# Altium schematic sheet 3A04xx_Oxocard_Mini_Science_MCU.SchDoc
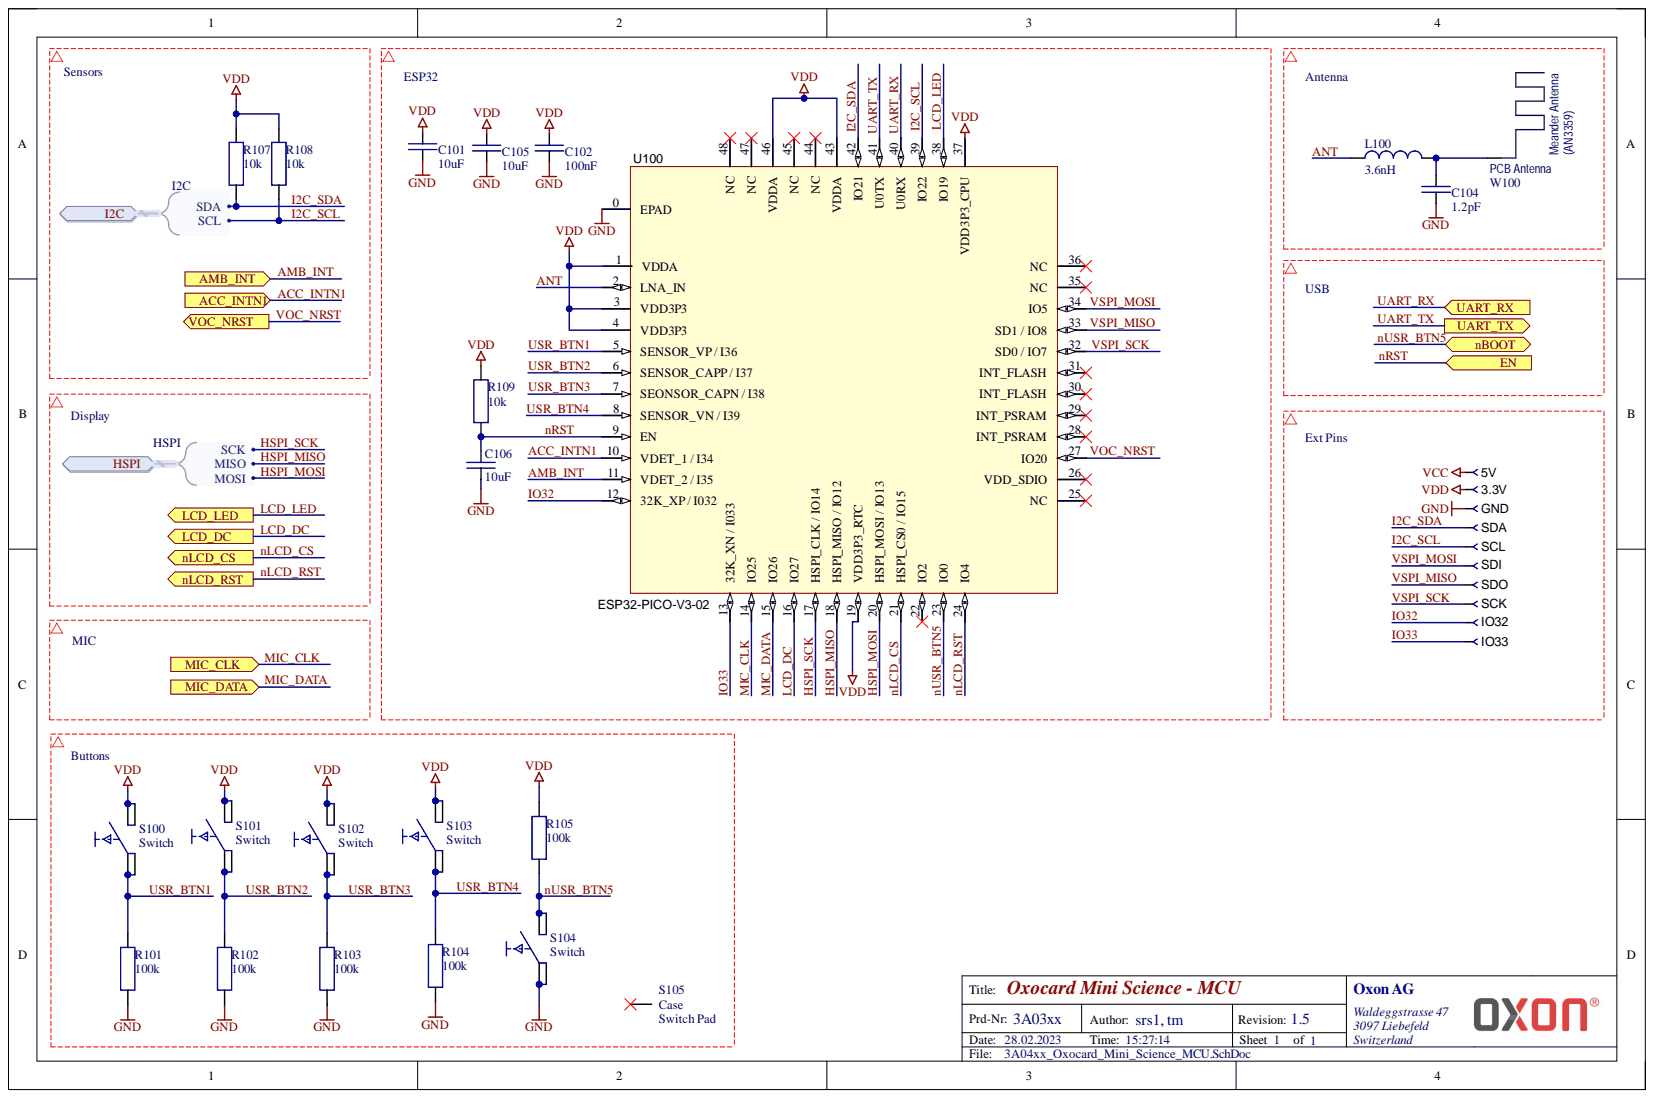
[diagram p0: 3A04xx_Oxocard_Mini_Science_MCU.SchDoc]

## Parts
3.3V  TP-2x3mm  [TP_2X3MM]  (value not drawn)
5V  TP-2x3mm  [TP_2X3MM]  (value not drawn)
C101  10uF  [CAPC1005X60]
C102  100nF  [CAPC1005X60]
C104  1.2pF  [CAPC1005X60]
C105  10uF  [CAPC1005X60]
C106  10uF  [CAPC1005X60]
GND  TP-2x3mm  [TP_2X3MM]  (value not drawn)
IO32  TP-2x3mm  [TP_2X3MM]  (value not drawn)
IO33  TP-2x3mm  [TP_2X3MM]  (value not drawn)
L100  3.6nH  [INDC1005X60]
R101  100k  [RESC1005X40]
R102  100k  [RESC1005X40]
R103  100k  [RESC1005X40]
R104  100k  [RESC1005X40]
R105  100k  [RESC1005X40]
R107  10k  [RESC1005X40]
R108  10k  [RESC1005X40]
R109  10k  [RESC1005X40]
S100  Switch  [Switch_Frog_7.6x7.6]
S101  Switch  [Switch_Frog_7.6x7.6]
S102  Switch  [Switch_Frog_7.6x7.6]
S103  Switch  [Switch_Frog_7.6x7.6]
S104  Switch  [Switch_Frog_7.6x7.6]
S105  Switch Pad  [Switch_PAD]
SCK  TP-2x3mm  [TP_2X3MM]  (value not drawn)
SCL  TP-2x3mm  [TP_2X3MM]  (value not drawn)
SDA  TP-2x3mm  [TP_2X3MM]  (value not drawn)
SDI  TP-2x3mm  [TP_2X3MM]  (value not drawn)
SDO  TP-2x3mm  [TP_2X3MM]  (value not drawn)
U100  ESP32-PICO-V3-02  [QFN48-7x7mm]
W100  BLE-Antenna  [W-BLE-PCB-ANT]  (value not drawn)

## Ports
ACC_INTN1, AMB_INT, EN, HSPI, HSPI.MISO, HSPI.MOSI, HSPI.SCK, I2C, I2C.SCL, I2C.SDA, LCD_DC, LCD_LED, MIC_CLK, MIC_DATA, nBOOT, nLCD_CS, nLCD_RST, UART_RX, UART_TX, VOC_NRST

## Nets
GND: C101.1 C102.1 C104.1 C105.1 C106.1 GND.1 R101.1 R102.1 R103.1 R104.1 S104.1 S104.2 U100.0(EPAD)
VCC: 5V.1
VDD: 3.3V.1 C101.2 C102.2 C105.2 R105.2 R107.2 R108.2 R109.2 S100.3 S100.4 S101.3 S101.4 S102.3 S102.4 S103.3 S103.4 U100.1(VDDA) U100.3(VDD3P3) U100.4(VDD3P3) U100.19(VDD3P3_RTC) U100.37(VDD3P3_CPU) U100.43(VDDA) U100.46(VDDA)
ACC_INTN1: U100.10(VDET_1_/_I34) ; port ACC_INTN1
AMB_INT: U100.11(VDET_2_/_I35) ; port AMB_INT
ANT: L100.1 U100.2(LNA_IN)
HSPI_MISO: U100.18(HSPI_MISO_/_IO12) ; port HSPI
HSPI_MOSI: U100.20(HSPI_MOSI_/_IO13) ; port HSPI
HSPI_SCK: U100.17(HSPI_CLK_/_IO14) ; port HSPI
I2C_SCL: R108.1 SCL.1 U100.39(IO22) ; port I2C
I2C_SDA: R107.1 SDA.1 U100.42(IO21) ; port I2C
IO32: IO32.1 U100.12(32K_XP_/_I032)
IO33: IO33.1 U100.13(32K_XN_/_I033)
LCD_DC: U100.16(IO27) ; port LCD_DC
LCD_LED: U100.38(IO19) ; port LCD_LED
MIC_CLK: U100.14(IO25) ; port MIC_CLK
MIC_DATA: U100.15(IO26) ; port MIC_DATA
nLCD_CS: U100.21(HSPI_CS0_/_IO15) ; port nLCD_CS
nLCD_RST: U100.24(IO4) ; port nLCD_RST
nRST: C106.2 R109.1 U100.9(EN) ; port EN
nUSR_BTN5: R105.1 S104.3 S104.4 U100.23(IO0) ; port nBOOT
UART_RX: U100.40(U0RX) ; port UART_RX
UART_TX: U100.41(U0TX) ; port UART_TX
USR_BTN1: R101.2 S100.1 S100.2 U100.5(SENSOR_VP_/_I36)
USR_BTN2: R102.2 S101.1 S101.2 U100.6(SENSOR_CAPP_/_I37)
USR_BTN3: R103.2 S102.1 S102.2 U100.7(SEONSOR_CAPN_/_I38)
USR_BTN4: R104.2 S103.1 S103.2 U100.8(SENSOR_VN_/_I39)
VOC_NRST: U100.27(IO20) ; port VOC_NRST
VSPI_MISO: SDO.1 U100.33(SD1_/_IO8)
VSPI_MOSI: SDI.1 U100.34(IO5)
VSPI_SCK: SCK.1 U100.32(SD0_/_IO7)
NetC104_2: C104.2 L100.2 W100.1

Not connected: S105 0; U100 22(IO2) 25(NC) 26(VDD_SDIO) 28(INT_PSRAM) 29(INT_PSRAM) 30(INT_FLASH) 31(INT_FLASH) 35(NC) 36(NC) 44(NC) 45(NC) 47(NC) 48(NC)

## Library data of the parts
3.3V 5V GND IO32 IO33 SCK SCL SDA SDI SDO = TP-20x30-SMT: Test Point, 2x3mm; Component Kind: Standard; Library Name: XLib.SCHLIB
C101 C105 C106 = C-CAP0402_10u: SMD capacitor 6.3V 20%; Manufacturer: Wurth Electronics; Manufacturer Designator: ZRB15XR60J106ME2D; Component Kind: Standard; Distributor: Mouser; Distributor Part-Number: 81-ZRB15XR60J106ME2D; Library Name: XLib.SCHLIB
C102 = C-CAP0402_100n: SMD capacitor 10V 5%; Manufacturer: Wurth Electronics; Manufacturer Designator: GRM155R61A104JA1D; Component Kind: Standard; Distributor: Mouser; Distributor Part-Number: 81-GRM155R61A104JA1D; Library Name: XLib.SCHLIB
C104 = C-CAP0402_1p2: SMD capacitor 50V +/-0.05pF; Manufacturer: Murata Electronics; Manufacturer Designator: GJM1555C1H1R2WB01J; Component Kind: Standard; Distributor: Mouser; Distributor Part-Number: 81-GJM1555C1H1R2WB1J; Library Name: XLib.SCHLIB
L100 = L-Ind00402_3n6: SMD induction 2%; Manufacturer: muRata; Manufacturer Designator: LQW15AN3N6G80D; Component Kind: Standard; Distributor: Mouser; Distributor Part-Number: 81-LQW15AN3N6G80D; Library Name: XLib.SCHLIB
R101-R105 = R-RES0402_100k: SMD resistor 1%; Manufacturer: Yageo; Manufacturer Designator: RC0402FR-07100KL; Component Kind: Standard; Distributor: Mouser; Distributor Part-Number: 603-RC0402FR-07100KL; Library Name: XLib.SCHLIB
R107-R109 = R-RES0402_10k: SMD resistor 1%; Manufacturer: Yageo; Manufacturer Designator: RC0402FR-0710KL; Component Kind: Standard; Distributor: Mouser; Distributor Part-Number: 603-RC0402FR-0710KL; Library Name: XLib.SCHLIB
S100-S104 = S-Frog-7.6x7.6: Switch Frog 7.6x7.6; Manufacturer: China; Component Kind: Standard; Library Name: XLib.SCHLIB
S105 = S-SwitchPad: Component Kind: Standard; Description: Switch pad; Library Name: XLib.SCHLIB
U100 = U-ESP32-PICO-V3-02: ESP32 8MB Flash 2MB PSRAM; Manufacturer: Espressif Systems; Component Kind: Standard; Distributor: Mouser; Distributor Part-Number: 356-ESP32-PICO-V3-02; Library Name: XLib.SCHLIB; Manufacturer Designator: ESP32-PICO-V3-02
W100 = W-BLE-PCB-Antenna: 2.4GHz PCB Antenna; Component Kind: Standard; Distributor: -; Distributor Part-Number: -; Library Name: XLib.SCHLIB; Manufacturer: -; Manufacturer Designator: -
